# Altium schematic sheet 8_LoRa.SchDoc(8_LoRa)
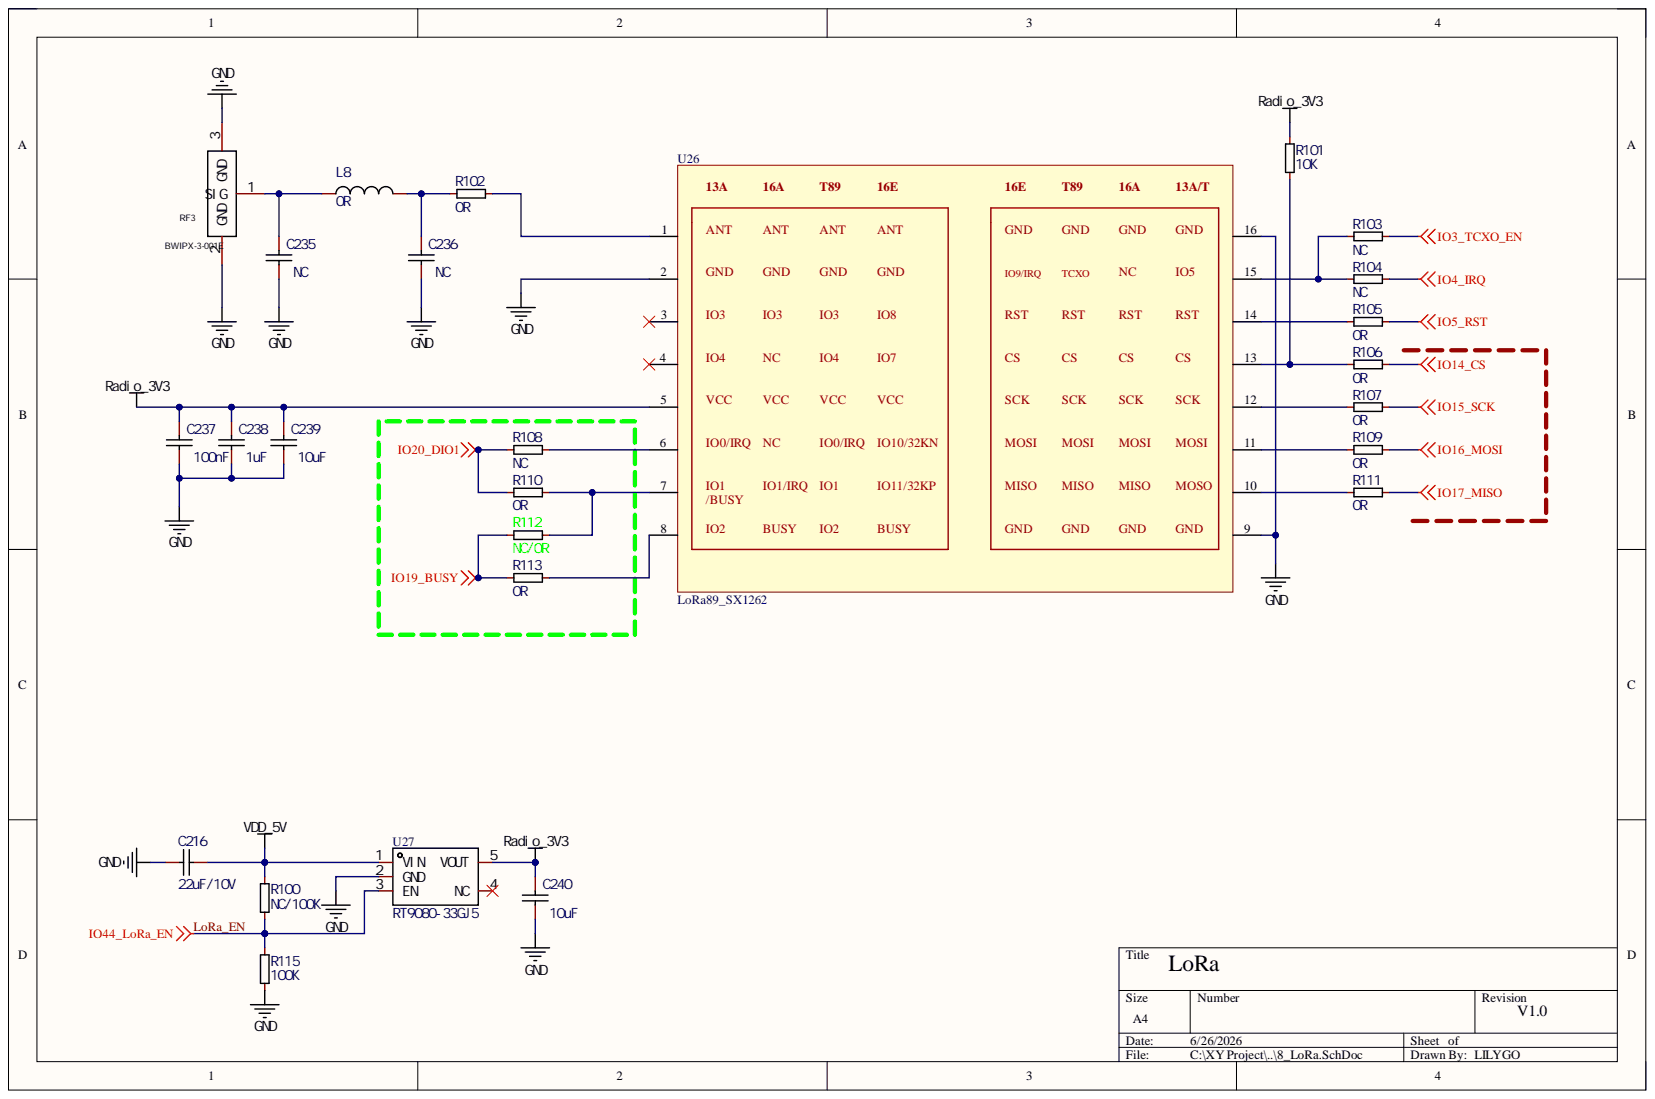
[diagram p0: 8_LoRa.SchDoc]

## Parts
C216  22uF/10V  [c0603]
C235  NC  [c0402]
C236  NC  [c0402]
C237  100nF  [c0402]
C238  1uF  [c0402]
C239  10uF  [c0603]
C240  10uF  [c0603]
L8  0R  [R0402]
R100  NC/100K  [r0402]
R101  10K  [r0402]
R102  0R  [R0402]
R103  NC  [r0402]
R104  NC  [r0402]
R105  0R  [r0402]
R106  0R  [r0402]
R107  0R  [r0402]
R108  NC  [r0402]
R109  0R  [r0402]
R110  0R  [r0402]
R111  0R  [r0402]
R112  NC/0R  [r0402]
R113  0R  [r0402]
R115  100K  [r0402]
RF3  BWIPX-3-001E  [RF-SMD_FRF05002-JSS103M]
U26  LoRa89_SX1262  [SX1262]
U27  RT9080-33GJ5  [TSOT-23-5_L2.9-W1.6-P0.95-LS2.8-BL]

## Nets
GND: C216.2 C235.2 C236.2 C237.2 C238.2 C239.2 C240.2 R115.2 RF3.2 RF3.3 U26.2 U26.9 U26.16 U27.2(GND)
IO3_TCXO_EN: R103.2
IO4_IRQ: R104.2
IO5_RST: R105.2
IO14_CS: R106.2
IO15_SCK: R107.2
IO16_MOSI: R109.2
IO17_MISO: R111.2
IO19_BUSY: R112.1 R113.1
IO20_DIO1: R108.1 R110.1
Radio_3V3: C237.1 C238.1 C239.1 C240.1 R101.2 U26.5 U27.5(VOUT)
VDD_5V: C216.1 R100.1 U27.1(VIN)
LoRa_EN: R100.2 R115.1 U27.3(EN)
NetC235_1: C235.1 L8.1 RF3.1
NetC236_1: C236.1 L8.2 R102.1
NetR101_1: R101.1 R106.1 U26.13
NetR102_2: R102.2 U26.1
NetR103_1: R103.1 R104.1 U26.15
NetR105_1: R105.1 U26.14
NetR107_1: R107.1 U26.12
NetR108_2: R108.2 U26.6
NetR109_1: R109.1 U26.11
NetR110_2: R110.2 R112.2 U26.7
NetR111_1: R111.1 U26.10
NetR113_2: R113.2 U26.8

Not connected: U26 3 4; U27 4(NC)

## Library data of the parts
C216 = CAP: Add into BOM: yes; Comment_1: 22uF/10V; Component Kind: Standard; Convert to PCB: yes; Device: CAP; Symbol: CAP
C235-C240 = CAP: Add into BOM: yes; Comment_1: 10nF; Component Kind: Standard; Convert to PCB: yes; Device: CAP; Symbol: CAP
L8 = Inductor: Add into BOM: yes; Comment_1: 2.2uH/&gt;2A; Component Kind: Standard; Convert to PCB: yes; Device: Inductor; PCB3D: inductor_3x3mm; Symbol: Inductor
R100-R113 R115 = RES: Add into BOM: yes; Comment_1: 75R; Component Kind: Standard; Convert to PCB: yes; Device: RES; Symbol: RES
RF3 = U.FL-R-SMT-1(80)_C88374: Manufacturer: HRS(广濑); Add into BOM: yes; Connector Type: 板端; Frequency-Max: 6GHz; Impedance: 50Ω; Interface Diameter: 2mm; Interface Length/Height: 1.25mm; Interface Type: 内针; Number of Ports: 1; RF Series: IPEX; Component Kind: Standard; Contact Material: 黄铜; Convert to PCB: yes; Datasheet: https://atta.szlcsc.com/upload/public/pdf/source/20161125/1480067868647.pdf; Device: U.FL-R-SMT-1(80)_C88374; JLCPCB Part Class: Extended Part; LCSC Part Name: IPEX 内针; Manufacturer Part: U.FL-R-SMT-1(80); Operating Temperature Range: -40℃~+90℃; PCB3D: RF-SMD_FRF05002-JSS103M; Style: -; Supplier: LCSC; Supplier Footprint: SMD; Supplier Part: C88374; Symbol: U.FL-R-SMT-1(80)
U26 = LoRa: Component Kind: Standard; Library Name: WendyLib.SchLib
U27 = RT9080-33GJ5: Manufacturer: RICHTEK(立锜); Add into BOM: yes; Dropout Voltage: 530mV@(600mA); MAX Output Current: 600mA; Maximum Input Voltage: 5.5V; Number of Outputs: 1; Output Polarity: 正极; Output Type: 固定; Output Voltage: 3.3V; Power Supply Rejection Ratio (PSRR): 75dB@(1kHz); standby current: 4uA; Component Kind: Standard; Convert to PCB: yes; Datasheet: https://atta.szlcsc.com/upload/public/pdf/source/20200918/C841192_D291BBB33AFC8816B317CCB22A728955.pdf; Device: RT9080-33GJ5; JLCPCB Part Class: Extended Part; LCSC Part Name: RT9080-33GJ5; Manufacturer Part: RT9080-33GJ5; Operating Temperature: -40℃~+85℃@(Ta); Supplier: LCSC; Supplier Footprint: TSOT-23-5; Supplier Part: C841192; Symbol: RT9080-33GJ5
